# Altium schematic sheet UART.SchDoc(UART)
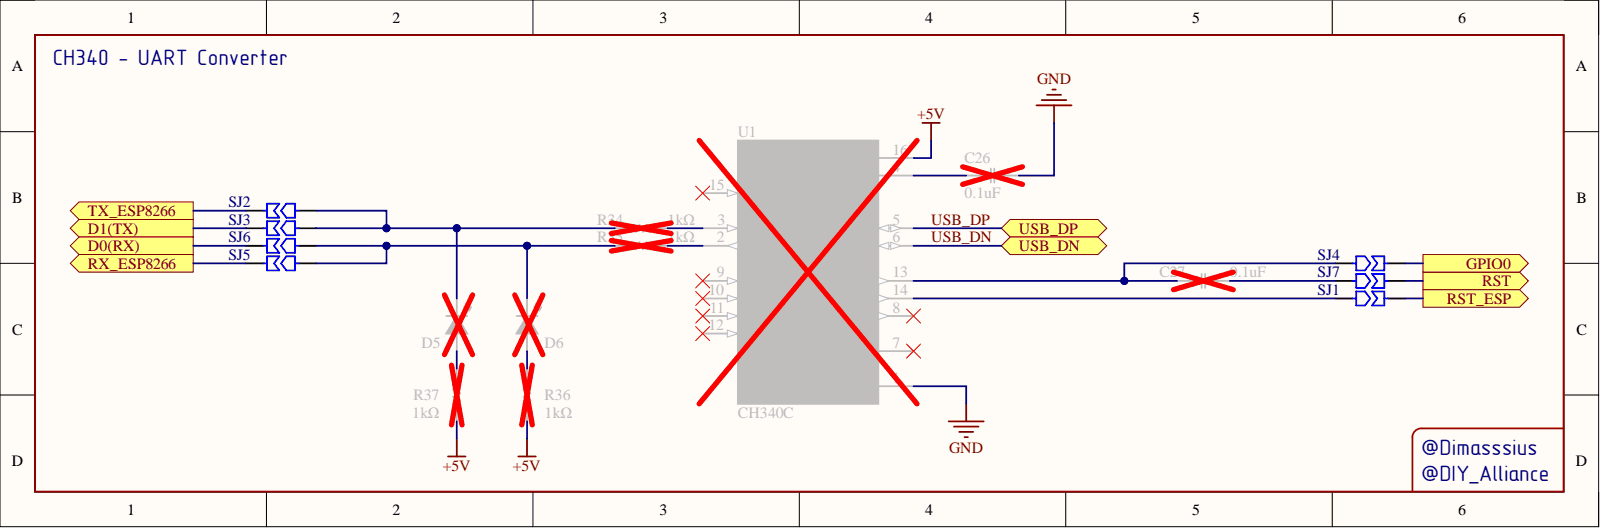
[diagram p0: UART.SchDoc]

## Parts
C26  0.1uF  [CAP_SMD_MLCC_C0603_(M1608)_C0402A_(M1005A)]
C27  0.1uF  [CAP_SMD_MLCC_C0603_(M1608)_C0402A_(M1005A)]
D5  LED0603  [LED 0603_(1608)_0402A_(1005)]  (value not drawn)
D6  LED0603  [LED 0603_(1608)_0402A_(1005)]  (value not drawn)
R34  1kΩ  [RES_SMD_R0603_(M1608)_R0402A_(M1005A)]
R35  1kΩ  [RES_SMD_R0603_(M1608)_R0402A_(M1005A)]
R36  1kΩ  [RES_SMD_R0603_(M1608)_R0402A_(M1005A)]
R37  1kΩ  [RES_SMD_R0603_(M1608)_R0402A_(M1005A)]
SJ1  Jumper  [JUMPER_0603]  (value not drawn)  (no BOM)
SJ2  Jumper  [JUMPER_0603]  (value not drawn)  (no BOM)
SJ3  Jumper  [JUMPER_0603]  (value not drawn)  (no BOM)
SJ4  Jumper  [JUMPER_0603]  (value not drawn)  (no BOM)
SJ5  Jumper  [JUMPER_0603]  (value not drawn)  (no BOM)
SJ6  Jumper  [JUMPER_0603]  (value not drawn)  (no BOM)
SJ7  Jumper  [JUMPER_0603]  (value not drawn)  (no BOM)
U1  CH340C  [SOIC127P600X180-16N]

## Ports
D0(RX), D1(TX), GPIO0, RST, RST_ESP, RX_ESP8266, TX_ESP8266, USB_DN, USB_DP

## Nets
+5V: R36.1 R37.1 U1.16(VCC)
GND: C26.2 U1.1(GND)
D0(RX): SJ6.1 ; port D0(RX)
D1(TX): SJ3.1 ; port D1(TX)
GPIO0: SJ4.1 ; port GPIO0
RST: SJ7.1 ; port RST
RST_ESP: SJ1.1 ; port RST_ESP
RX_ESP8266: SJ5.1 ; port RX_ESP8266
TX_ESP8266: SJ2.1 ; port TX_ESP8266
USB_DN: U1.6(UD-) ; port USB_DN
USB_DP: U1.5(UD+) ; port USB_DP
NetC26_1: C26.1 U1.4(V3)
NetC27_1: C27.1 SJ4.2 U1.13(DTR)
NetC27_2: C27.2 SJ7.2
NetD5_1: D5.1 R34.1 SJ2.2 SJ3.2
NetD5_2: D5.2 R37.2
NetD6_1: D6.1 R35.1 SJ5.2 SJ6.2
NetD6_2: D6.2 R36.2
NetR34_2: R34.2 U1.3(RXD)
NetR35_2: R35.2 U1.2(TXD)
NetSJ1_2: SJ1.2 U1.14(RTS)

Not connected: U1 7(NC.) 8(OUT) 9(CTS) 10(DSR) 11(RI) 12(DCD) 15(R232)

## Library data of the parts
C26 C27 = CAP_SMD_MLCC_0.1UF_6.3V: Capacitor, Ceramic (MLCC), SMD, 100nF, 6.3V, ±10%, X7R, Multilayer Ceramic Capacitors MLCC - SMD/SMT, ROHS; Manufacturer: Murata Electronics North America; Part Number: LLM315R71C104MA11L; @ qty: 0; Minimum Quantity: 1; Tolerance: ±20%; Unit Price (USD): 0.9; Voltage: 16V; Applications: Bypass, Decoupling; Component Kind: Standard; Datasheets: http://www.murata.com/~/media/webrenewal/support/library/catalog/products/capacitor/mlcc/c02e.pdf; Digi-Key Part Number: 490-4495-1-ND; Factory Stock: 0; Features: Low ESL (Multi-Terminal); Height - Seated (Max): -; Image: //media.digikey.com/Renders/Murata%20Renders/1206(LLM315).jpg; Lead Spacing: -; Lead Style: -; Library Name: Capacitors.SchLib; Mounting Type: Surface Mount, MLCC; Operating Temperature: -55°C ~ 125°C; Package / Case: -; Packaging: Cut Tape (CT); Part Status: Active; Quantity Available: 2915; Ratings: -; Series: LLM; Size / Dimension: -; Temperature Coefficient: X7R; Thickness (Max): -; Value: 0.1uF
D5 D6 = XL-1608SURC-06: LED Indication - Discrete, 0603, 20mA, 225mcd, Colorless Transparent Lens, -20℃~+85℃, Positive Stick, 620nm~630nm, Red, 120°, 50mW, 2.3V, LED Indication - Discrete, ROHS; Component Kind: Standard; Datasheet Link: https://katalog.we-online.de/led/datasheet/150060GS55040.pdf; Height: 0.5mm; Library Name: SamacSys.SchLib; Value: LED0603
R34-R37 = RES_SMD_1KΩ: Resistor, Thick Film Resistor, SMD, 1kΩ, ±5%, Chip Resistor - Surface Mount, ROHS; Component Kind: Standard; Library Name: Resistors.SchLib; Value: 1kΩ
SJ1-SJ7 = JUMPER SINGLE: Jumper Solder; Library Name: SamacSys.SchLib; Value: Jumper
U1 = CH340C: USB Converter, SOP-16, 2Mbps Transceiver, USB 2.0, USB Converters,  ROHS; Component Kind: Standard; Library Name: SamacSys.SchLib; Value: CH340C
